# Altium schematic sheet Robot_car.SchDoc
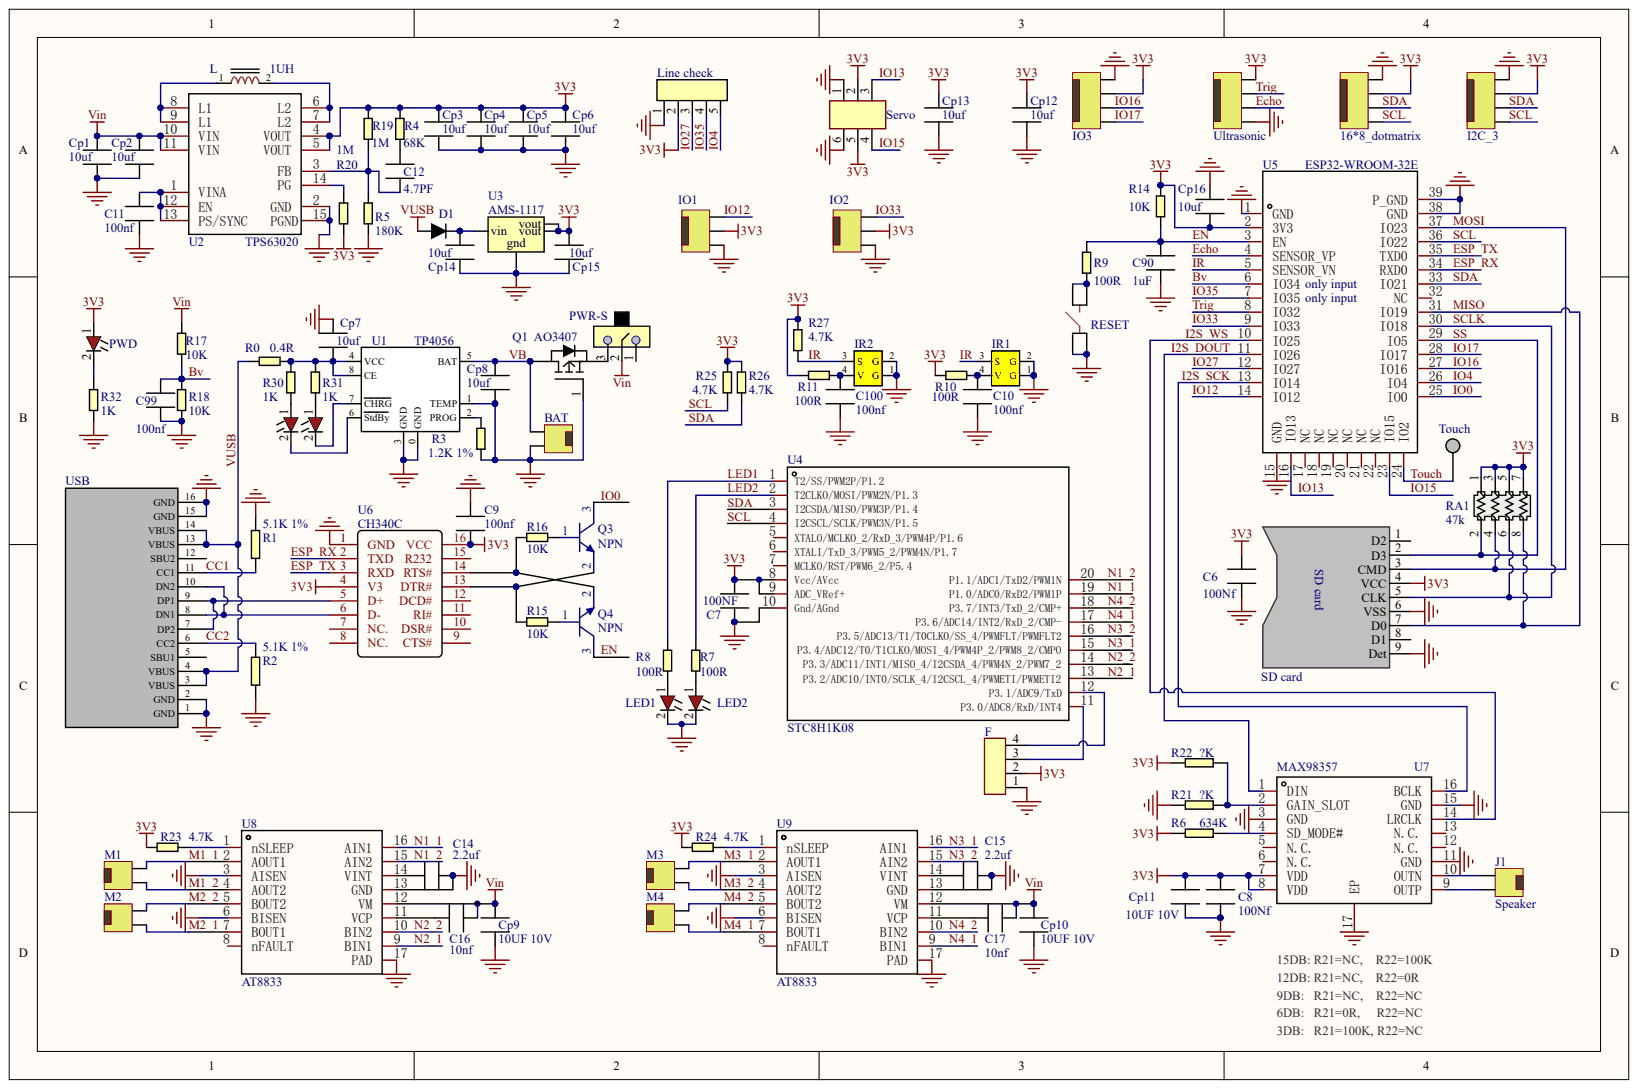
[diagram p0: Robot_car.SchDoc]

## Parts
16*8_dotmatrix  CONNECTOR-2.54MM-4P  (value not drawn)
BAT  BAT
C6  100Nf
C7  100NF
C8  100Nf
C9  100nf
C10  100nf
C11  100nf
C12  4.7PF
C14  2.2uf
C15  2.2uf
C16  10nf
C17  10nf
C90  1uF
C99  100nf
C100  100nf
Cp1  10uf
Cp2  10uf
Cp3  10uf
Cp4  10uf
Cp5  10uf
Cp6  10uf
Cp7  10uf
Cp8  10uf
Cp9  10UF 10V
Cp10  10UF 10V
Cp11  10UF 10V
Cp12  10uf
Cp13  10uf
Cp14  10uf
Cp15  10uf
Cp16  10uf
D1  Diode  (value not drawn)
F  Connector-4P  (value not drawn)
Green  LED  (value not drawn)
I2C_3  CONNECTOR-2.54MM-4P  (value not drawn)
IO1  CONNECTOR-2.54MM-3P  (value not drawn)
IO2  CONNECTOR-2.54MM-3P  (value not drawn)
IO3  CONNECTOR-2.54MM-4P  (value not drawn)
IR1  IRM-H638T/TR2  (value not drawn)
IR2  IRM-H638T/TR2  (value not drawn)
J1  Speaker
L  1UH
LED1  LED
LED2  LED
Line_check  Connector-5P  (value not drawn)
M1  CONNECTOR-2.54MM-2P  (value not drawn)
M2  CONNECTOR-2.54MM-2P  (value not drawn)
M3  CONNECTOR-2.54MM-2P  (value not drawn)
M4  CONNECTOR-2.54MM-2P  (value not drawn)
PWD  white  (value not drawn)
PWR-S  SS-12F21G3  (value not drawn)
Q1  AO3407
Q3  NPN
Q4  NPN
R0  0.4R
R1  5.1K 1%
R2  5.1K 1%
R3  1.2K 1%
R4  68K
R5  180K
R6  634K
R7  100R
R8  100R
R9  100R
R10  100R
R11  100R
R14  10K
R15  10K
R16  10K
R17  10K
R18  10K
R19  1M
R20  1M
R21  ?K
R22  ?K
R23  4.7K
R24  4.7K
R25  4.7K
R26  4.7K
R27  4.7K
R30  1K
R31  1K
R32  1K
RA1  47k
Red  LED  (value not drawn)
RESET  KEY-4P  (value not drawn)
SD  SD card
Servo  Connector-2x3  (value not drawn)
Touch  Port  (value not drawn)
U1  TP4056
U2  TPS63020
U3  AMS-1117
U4  STC8H1K08
U5  ESP32-WROOM-32E
U6  CH340C
U7  MAX98357
U8  AT8833
U9  AT8833
Ultrasonic  CONNECTOR-2.54MM-4P  (value not drawn)
USB  Type_C_16P  (value not drawn)

## Nets
3V3: 16*8_dotmatrix.3 C6.2 C7.2 C8.2 C9.2 Cp3.2 Cp4.2 Cp5.2 Cp6.2 Cp11.2 Cp12.2 Cp13.2 Cp15.2 Cp16.1 F.2 I2C_3.3 IO1.2 IO2.2 IO3.3 Line_check.2 PWD.1 R4.2 R6.2 R10.1 R11.1 R14.2 R19.2 R20.1 R22.2 R23.2 R24.2 R25.1 R26.1 R27.2 RA1.1 RA1.3 RA1.5 RA1.7 SD.4(VCC) Servo.2 Servo.5 U2.4(VOUT) U2.5(VOUT) U3.2(vout) U3.4(vout) U4.8(Vcc/AVcc) U4.9(ADC_VRef+) U5.2(3V3) U6.4(V3) U6.16(VCC) U7.7(VDD) U7.8(VDD) Ultrasonic.4
GND: 16*8_dotmatrix.4 BAT.1 C6.1 C7.1 C8.1 C9.1 C10.2 C11.1 C14.1 C15.1 C90.2 C99.2 C100.2 Cp1.1 Cp2.1 Cp3.1 Cp4.1 Cp5.1 Cp6.1 Cp7.2 Cp8.1 Cp9.1 Cp10.1 Cp11.1 Cp12.1 Cp13.1 Cp14.1 Cp15.1 Cp16.2 F.1 I2C_3.4 IO1.3 IO2.3 IO3.4 IR1.1(G) IR1.2(G) IR2.1(G) IR2.2(G) LED1.2 LED2.2 Line_check.1 Q1.1 R1.2 R2.2 R3.1 R5.1 R18.1 R21.2 R32.1 RESET.1 RESET.2 SD.6(VSS) SD.9(Det) Servo.1 Servo.6 U1.0(GND) U1.1(TEMP) U1.3(GND) U2.2(GND) U2.15(PGND) U3.1 U4.10(Gnd/AGnd) U5.1(GND) U5.15(GND) U5.38(GND) U5.39(P_GND) U6.1(GND) U7.3(GND) U7.11(GND) U7.15(GND) U7.17(EP) U8.3(AISEN) U8.6(BISEN) U8.13(GND) U8.17(PAD) U9.3(AISEN) U9.6(BISEN) U9.13(GND) U9.17(PAD) Ultrasonic.1 USB.1(GND) USB.2(GND) USB.15(GND) USB.16(GND)
VIN: C16.1 C17.1 Cp1.2 Cp2.2 Cp9.2 Cp10.2 PWR-S.2 R17.2 U2.10(VIN) U2.11(VIN) U8.12(VM) U9.12(VM)
BV: C99.1 R17.1 R18.2 U5.6(IO34)
CC1: R1.1 USB.11(CC1)
CC2: R2.1 USB.6(CC2)
ECHO: U5.4(SENSOR_VP) Ultrasonic.2
EN: C90.1 Q4.3 R9.2 R14.1 U5.3(EN)
ESP_RX: U5.34(RXD0) U6.2(TXD)
ESP_TX: U5.35(TXD0) U6.3(RXD)
I2S_DOUT: U5.11(IO26) U7.1(DIN)
I2S_SCK: U5.13(IO14) U7.16(BCLK)
I2S_WS: U5.10(IO25) U7.14(LRCLK)
IO0: Q3.3 U5.25(IO0)
IO4: Line_check.5 U5.26(IO4)
IO12: IO1.1 U5.14(IO12)
IO13: Servo.3 U5.16(IO13)
IO15: Servo.4 U5.23(IO15)
IO16: IO3.2 U5.27(IO16)
IO17: IO3.1 U5.28(IO17)
IO27: Line_check.3 U5.12(IO27)
IO33: IO2.1 U5.9(IO33)
IO35: Line_check.4 U5.7(IO35)
IR: IR1.3(S) IR2.3(S) R27.1 U5.5(SENSOR_VN)
LED1: R8.2 U4.1(T2/SS/PWM2P/P1.2)
LED2: R7.2 U4.2(T2CLKO/MOSI/PWM2N/P1.3)
M1_1: M1.2 U8.2(AOUT1)
M1_2: M1.1 U8.4(AOUT2)
M2_1: M2.1 U8.7(BOUT1)
M2_2: M2.2 U8.5(BOUT2)
M3_1: M3.2 U9.2(AOUT1)
M3_2: M3.1 U9.4(AOUT2)
M4_1: M4.1 U9.7(BOUT1)
M4_2: M4.2 U9.5(BOUT2)
MISO: RA1.8 SD.7(D0) U5.31(IO19)
MOSI: RA1.4 SD.3(CMD) U5.37(IO23)
N1_1: U4.19(P1.0/ADC0/RxD2/PWM1P) U8.16(AIN1)
N1_2: U4.20(P1.1/ADC1/TxD2/PWM1N) U8.15(AIN2)
N2_1: U4.13(P3.2/ADC10/INT0/SCLK_4/I2CSCL_4/PWMETI/PWMETI2) U8.9(BIN1)
N2_2: U4.14(P3.3/ADC11/INT1/MISO_4/I2CSDA_4/PWM4N_2/PWM7_2) U8.10(BIN2)
N3_1: U4.15(P3.4/ADC12/T0/T1CLKO/MOSI_4/PWM4P_2/PWM8_2/CMPO) U9.16(AIN1)
N3_2: U4.16(P3.5/ADC13/T1/T0CLKO/SS_4/PWMFLT/PWMFLT2) U9.15(AIN2)
N4_1: U4.17(P3.6/ADC14/INT2/RxD_2/CMP-) U9.9(BIN1)
N4_2: U4.18(P3.7/INT3/TxD_2/CMP+) U9.10(BIN2)
SCL: 16*8_dotmatrix.1 I2C_3.1 R25.2 U4.4(I2CSCL/SCLK/PWM3N/P1.5) U5.36(IO22)
SCLK: RA1.6 SD.5(CLK) U5.30(IO18)
SDA: 16*8_dotmatrix.2 I2C_3.2 R26.2 U4.3(I2CSDA/MISO/PWM3P/P1.4) U5.33(IO21)
SS: RA1.2 SD.2(D3) U5.29(IO5)
TOUCH: Touch.1 U5.24(IO2)
TRIG: U5.8(IO32) Ultrasonic.3
VB: BAT.2 Cp8.2 Q1.3 U1.5(BAT)
VUSB: D1.1 R0.2 USB.3(VBUS) USB.4(VBUS) USB.13(VBUS) USB.14(VBUS)
NetC10_1: C10.1 IR1.4(V) R10.2
NetC11_2: C11.2 U2.1(VINA) U2.12(EN) U2.13(PS/SYNC)
NetC12_1: C12.1 R5.2 R19.1 U2.3(FB)
NetC12_2: C12.2 R4.1
NetC14_2: C14.2 U8.14(VINT)
NetC15_2: C15.2 U9.14(VINT)
NetC16_2: C16.2 U8.11(VCP)
NetC17_2: C17.2 U9.11(VCP)
NetC100_1: C100.1 IR2.4(V) R11.2
NetCp7_1: Cp7.1 R0.1 R30.1 R31.1 U1.4(VCC) U1.8(CE)
NetCp14_2: Cp14.2 D1.2 U3.3(vin)
NetF_3: F.3 U4.11(P3.0/ADC8/RxD/INT4)
NetF_4: F.4 U4.12(P3.1/ADC9/TxD)
NetGreen_1: Green.1 R30.2
NetGreen_2: Green.2 U1.6(StdBy)
NetJ1_1: J1.1 U7.9(OUTP)
NetJ1_2: J1.2 U7.10(OUTN)
NetL_1: L.1 U2.8(L1) U2.9(L1)
NetL_2: L.2 U2.6(L2) U2.7(L2)
NetLED1_1: LED1.1 R8.1
NetLED2_1: LED2.1 R7.1
NetPWD_2: PWD.2 R32.2
NetPWR-S_3: PWR-S.3 Q1.2
NetQ3_1: Q3.1 R16.2
NetQ3_2: Q3.2 R15.1 U6.13(DTR#)
NetQ4_1: Q4.1 R15.2
NetQ4_2: Q4.2 R16.1 U6.14(RTS#)
NetR3_2: R3.2 U1.2(PROG)
NetR6_1: R6.1 U7.4(SD_MODE#)
NetR9_1: R9.1 RESET.3 RESET.4
NetR20_2: R20.2 U2.14(PG)
NetR21_1: R21.1 R22.1 U7.2(GAIN_SLOT)
NetR23_1: R23.1 U8.1(nSLEEP)
NetR24_1: R24.1 U9.1(nSLEEP)
NetR31_2: R31.2 Red.1
NetRed_2: Red.2 U1.7(CHRG)
NetU6_5: U6.5(D+) USB.7(DP2) USB.9(DP1)
NetU6_6: U6.6(D-) USB.8(DN1) USB.10(DN2)

Not connected: PWR-S 1; SD 1(D2) 8(D1); U4 5(XTALO/MCLKO_2/RxD_3/PWM4P/P1.6) 6(XTALI/TxD_3/PWM5_2/PWM4N/P1.7) 7(MCLKO/RST/PWM6_2/P5.4); U5 17(NC) 18(NC) 19(NC) 20(NC) 21(NC) 22(NC) 32(NC); U6 7(NC.) 8(NC.) 9(CTS#) 10(DSR#) 11(RI#) 12(DCD#) 15(R232); U7 5(N.C.) 6(N.C.) 12(N.C.) 13(N.C.); U8 8(nFAULT); U9 8(nFAULT); USB 5(SBU1) 12(SBU2)

## Library data of the parts
16*8_dotmatrix BAT C6-C12 C14-C17 C90 C99 C100 Cp1-Cp16 D1 F Green I2C_3 IO1-IO3 IR1 IR2 J1 L LED1 LED2 Line_check M1-M4 PWD PWR-S Q1 Q3 Q4 R0-R11 R14-R27 R30-R32 RA1 Red RESET SD Servo Touch U1-U9 Ultrasonic USB = (no symbol name)
  D1: : *
